# Altium schematic sheet [03]_Valve_Control.SchDoc([03]_Valve_Control)
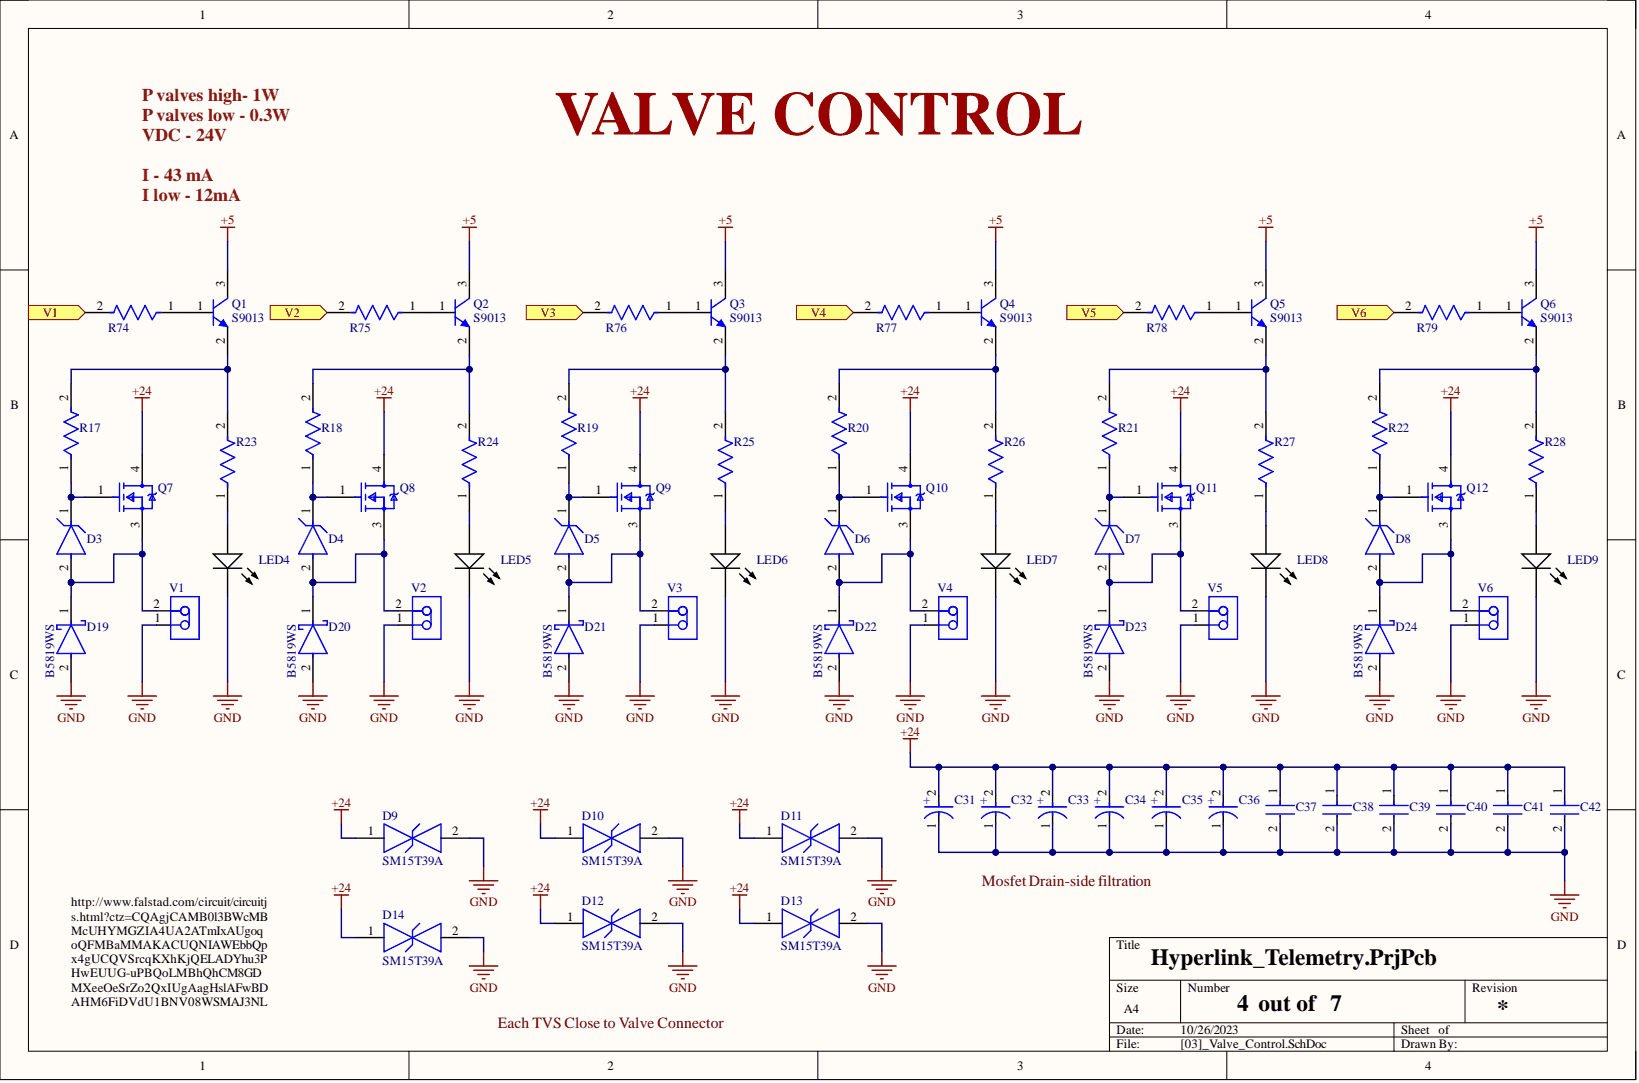
[diagram p0: [03]_Valve_Control.SchDoc]

## Parts
C31  EEEFK1V220R  (value not drawn)
C32  EEEFK1V220R  (value not drawn)
C33  EEEFK1V220R  (value not drawn)
C34  EEEFK1V220R  (value not drawn)
C35  EEEFK1V220R  (value not drawn)
C36  EEEFK1V220R  (value not drawn)
C37  TMK107BBJ475KA-T  (value not drawn)
C38  TMK107BBJ475KA-T  (value not drawn)
C39  TMK107BBJ475KA-T  (value not drawn)
C40  TMK107BBJ475KA-T  (value not drawn)
C41  TMK107BBJ475KA-T  (value not drawn)
C42  TMK107BBJ475KA-T  (value not drawn)
D3  BZT52C15  (value not drawn)
D4  BZT52C15  (value not drawn)
D5  BZT52C15  (value not drawn)
D6  BZT52C15  (value not drawn)
D7  BZT52C15  (value not drawn)
D8  BZT52C15  (value not drawn)
D9  SM15T39A
D10  SM15T39A
D11  SM15T39A
D12  SM15T39A
D13  SM15T39A
D14  SM15T39A
D19  B5819WS
D20  B5819WS
D21  B5819WS
D22  B5819WS
D23  B5819WS
D24  B5819WS
LED4  HSMW-C191  (value not drawn)
LED5  HSMW-C191  (value not drawn)
LED6  HSMW-C191  (value not drawn)
LED7  HSMW-C191  (value not drawn)
LED8  HSMW-C191  (value not drawn)
LED9  HSMW-C191  (value not drawn)
Q1  S9013
Q2  S9013
Q3  S9013
Q4  S9013
Q5  S9013
Q6  S9013
Q7  IRFR3410TRPBF  (value not drawn)
Q8  IRFR3410TRPBF  (value not drawn)
Q9  IRFR3410TRPBF  (value not drawn)
Q10  IRFR3410TRPBF  (value not drawn)
Q11  IRFR3410TRPBF  (value not drawn)
Q12  IRFR3410TRPBF  (value not drawn)
R17  0603WAF1200T5E  (value not drawn)
R18  0603WAF1200T5E  (value not drawn)
R19  0603WAF1200T5E  (value not drawn)
R20  0603WAF1200T5E  (value not drawn)
R21  0603WAF1200T5E  (value not drawn)
R22  0603WAF1200T5E  (value not drawn)
R23  CRCW06031K00FKEA  (value not drawn)
R24  CRCW06031K00FKEA  (value not drawn)
R25  CRCW06031K00FKEA  (value not drawn)
R26  CRCW06031K00FKEA  (value not drawn)
R27  CRCW06031K00FKEA  (value not drawn)
R28  CRCW06031K00FKEA  (value not drawn)
R74  0603WAF1200T5E  (value not drawn)
R75  0603WAF1200T5E  (value not drawn)
R76  0603WAF1200T5E  (value not drawn)
R77  0603WAF1200T5E  (value not drawn)
R78  0603WAF1200T5E  (value not drawn)
R79  0603WAF1200T5E  (value not drawn)
V1  1755736  (value not drawn)
V2  1755736  (value not drawn)
V3  1755736  (value not drawn)
V4  1755736  (value not drawn)
V5  1755736  (value not drawn)
V6  1755736  (value not drawn)

## Ports
V1, V2, V3, V4, V5, V6

## Nets
+5: Q1.3 Q2.3 Q3.3 Q4.3 Q5.3 Q6.3
+24: C31.2 C32.2 C33.2 C34.2 C35.2 C36.2 C37.1 C38.1 C39.1 C40.1 C41.1 C42.1 D9.1 D10.1 D11.1 D12.1 D13.1 D14.1 Q7.4 Q8.4 Q9.4 Q10.4 Q11.4 Q12.4
GND: C31.1 C32.1 C33.1 C34.1 C35.1 C36.1 C37.2 C38.2 C39.2 C40.2 C41.2 C42.2 D9.2 D10.2 D11.2 D12.2 D13.2 D14.2 D19.2 D20.2 D21.2 D22.2 D23.2 D24.2 LED4.2 LED5.2 LED6.2 LED7.2 LED8.2 LED9.2 V1.1 V2.1 V3.1 V4.1 V5.1 V6.1
V1: R74.2 ; port V1
V2: R75.2 ; port V2
V3: R76.2 ; port V3
V4: R77.2 ; port V4
V5: R78.2 ; port V5
V6: R79.2 ; port V6
NetD3_1: D3.1 Q7.1 R17.1
NetD3_2: D3.2 D19.1 Q7.3 V1.2
NetD4_1: D4.1 Q8.1 R18.1
NetD4_2: D4.2 D20.1 Q8.3 V2.2
NetD5_1: D5.1 Q9.1 R19.1
NetD5_2: D5.2 D21.1 Q9.3 V3.2
NetD6_1: D6.1 Q10.1 R20.1
NetD6_2: D6.2 D22.1 Q10.3 V4.2
NetD7_1: D7.1 Q11.1 R21.1
NetD7_2: D7.2 D23.1 Q11.3 V5.2
NetD8_1: D8.1 Q12.1 R22.1
NetD8_2: D8.2 D24.1 Q12.3 V6.2
NetLED4_1: LED4.1 R23.1
NetLED5_1: LED5.1 R24.1
NetLED6_1: LED6.1 R25.1
NetLED7_1: LED7.1 R26.1
NetLED8_1: LED8.1 R27.1
NetLED9_1: LED9.1 R28.1
NetQ1_1: Q1.1 R74.1
NetQ1_2: Q1.2 R17.2 R23.2
NetQ2_1: Q2.1 R75.1
NetQ2_2: Q2.2 R18.2 R24.2
NetQ3_1: Q3.1 R76.1
NetQ3_2: Q3.2 R19.2 R25.2
NetQ4_1: Q4.1 R77.1
NetQ4_2: Q4.2 R20.2 R26.2
NetQ5_1: Q5.1 R78.1
NetQ5_2: Q5.2 R21.2 R27.2
NetQ6_1: Q6.1 R79.1
NetQ6_2: Q6.2 R22.2 R28.2

## Library data of the parts
C31-C36 = CMP-05427-000063-1: CAP ALUM 22UF 20% 35V SMD; Dielectric Material: Aluminium; ESR (Equivalent Series Resistance): 700mR; Leakage Current: 3uA; Life (Hours): 2000Hour; Ripple Current: 160mA; Capacitance: 22uF; Case/Package: Radial; Component Kind: Standard; Construction: Cylindrical; Contact Plating: Tin; Diameter: 5mm; Height: 5.8mm; Height - Seated (Max): 0.228inch; Impedance: 700mR; JLC: C249982; Lead Free: Lead Free; Length: 5.8mm; Library Name: Altium Content Vault; Material: Aluminium; Max Operating Temperature: 105°C; Min Operating Temperature: -55°C; Mount: Surface Mount; Number of Pins: 2; Package Quantity: 1; Packaging: Tape and Reel; Radiation Hardening: No; REACH SVHC: No SVHC; Ripple Current (AC): 160mA; RoHS Compliant: Yes; Series: FK; Termination: SMD/SMT; Tolerance: 20%; Voltage: 35V; Voltage Rating: 35V; Voltage Rating (DC): 35V; Width: 6.5mm
C37-C42 = CMP-14477-004926-2: Multilayer Ceramic Capacitor 4.7uF 25V X5R 10% SMD 0603 T/R; Capacitance: 4.7uF; Case Code (Imperial): 0603; Case Code (Metric): 1608; Case/Package: 0603; Component Kind: Standard; Contact Plating: Tin; Dielectric: X5R; JLC: C19666; Lead Free: Lead Free; Length: 0.063inch; Library Name: Altium Content Vault; Max Operating Temperature: 85°C; Min Operating Temperature: -55°C; Mount: Surface Mount; Packaging: Tape and Reel; RoHS Compliant: Yes; Termination: Wraparound; Thickness: 0.039inch; Tolerance: 10%; Voltage Rating: 25V; Width: 0.031inch
D3-D8 = CMP-2000-05976-2: DIODE ZENER 15V 500MW SOD123; Manufacturer: Diodes Incorporated; Manufacturer Part Number: BZT52C5V1-7-F; Automotive Grade: NA; Forward Current: 10mA; Max Junction Temp: +150°C; Max Reverse Leakage Current: 2uA; Max Zener Voltage: 5.4V; Nom Zener Voltage: 5.1V; Power Dissipation: 370mW; Standoff Height: 0mm; Zener Impedance: 60Ω; Automotive: No; Category: Diode; Component Kind: Standard; ComponentLink2Description: Datasheet; ComponentLink2URL: https://www.diodes.com/assets/Datasheets/ds18004.pdf; Device Class L1: Discrete Semiconductors; Device Class L2: Diodes; Device Class L3: Zener Diodes; Digikey Description: DIODE ZENER 5.1V 500MW SOD123; Footprint Url: https://www.diodes.com/assets/Package-Files/SOD123.pdf; Height: 1.45mm; JLC: C173427; Lead Free: Yes; Library Name: Altium Content Vault; Mouser Description: Zener Diodes 5.1V 500mW; Octopart: https://octopart.com/bzt52c5v1-7-f-diodes+inc.-326718; Package: SOD123; RoHS: TRUE; Temperature: -65°C to +150°C; Temperature Range High: +150°C; Temperature Range Low: -65°C
D9-D14 = CMP-12225-000046-1: TVS DIODE 39V; Manufacturer: STMicroelectronics; Manufacturer Part Number: SM15T33CAY; Automotive Grade: Grade 0; Breakdown Voltage: 31.4V; Clamping Voltage: 45.7V; Direction: Bidirectional; Max Junction Temp: +150°C; Number Of Channels: 1; Peak Pulse Current: 33A; Peak Pulse Power: 1500W; Reverse Standoff Voltage: 28.2V; Standoff Height: 0.05mm; Automotive: Yes; Category: Diode; Component Kind: Standard; ComponentLink2Description: Datasheet; ComponentLink2URL: https://www.st.com/resource/en/datasheet/sm15ty.pdf; Device Class L1: Circuit Protection; Device Class L2: TVS Diodes; Device Class L3: unset; Digikey Description: TVS DIODE 28.2V 59V SMC; Height: 2.65mm; JLC: C1976564; Lead Free: Yes; Library Name: Altium Content Vault; Mouser Description: TVS Diodes - Transient Voltage Suppressors 1500 W 10kW Transil 5.8V tp 70V BI; Octopart: https://octopart.com/sm15t33cay-stmicroelectronics-19039450; Package: SMC-CA; RoHS: TRUE; Temperature: -40°C to +150°C; Temperature Range High: +150°C; Temperature Range Low: -40°C
D19-D24 = CMP-56206-000009-1: DIODE SCHOTTKY 40V 1A SOD323; Element Configuration: Single; Forward Voltage: 900mV; Max Reverse Leakage Current: 1mA; Max Surge Current: 10A; Average Rectified Current: 1A; Component Kind: Standard; JLC: C8598; Lead Free: Lead Free; Library Name: Altium Content Vault; Max Operating Temperature: 125°C; Max Reverse Voltage (DC): 40V; Min Operating Temperature: -65°C; Mount: Surface Mount; Number of Pins: 2; Package Quantity: 1; Packaging: Tape and Reel; Peak Non-Repetitive Surge Current: 10A; Radiation Hardening: No; RoHS Compliant: Yes; Series: -
LED4-LED9 = CMP-1744-00001-1: LED Uni-Color GREEN, 78 mW, 20 mA, -40 to 85 degC, 2-Pin SMD, RoHS, Tape and Reel; Current Rating: 300 mA; Component Kind: Standard; ComponentLink1Description: Manufacturer URL; ComponentLink1URL: http://www.avagotech.com/; ComponentLink2Description: Datasheet; ComponentLink2URL: http://docs.avagotech.com/docs/AV02-0186EN; Contact Finish: Silver; Contact Material: Copper; Contact Plating: Silver; DatasheetVersion: May-10; Height: 12 mm; JLC: C72038; Length: 16 mm; Library Name: Altium Content Vault; Max Operating Temperature: 85 degC; Min Operating Temperature: -20 degC; Mount: Through Hole; Package Quantity: 560; PackageDescription: 2-Pin Surface Mount Device, Body 1.6 x 0.8 mm; PackageReference: HSMW-C191; Packaging: Bulk; Radiation Hardening: No; REACH SVHC: Unknown; RoHSCompliant: true; Width: 12 mm
Q1-Q6 = CMP-1048-00662-1: NPN Silicon, 3-Pin SOT-23, Pb-Free, Tape and Reel; Manufacturer: On Semiconductor; PartNumber: MMBTA42LT1G; fT Min (MHz): 50; IC Continuous (A): 0.5; Component Kind: Standard; ComponentLink1Description: Manufacturer URL; ComponentLink1URL: http://www.onsemi.com/; ComponentLink2Description: Datasheet; ComponentLink2URL: http://www.onsemi.cn/pub_link/Collateral/MMBTA42LT1-D.PDF; ComponentLink3Description: Package Specification; ComponentLink3URL: http://www.onsemi.cn/pub_link/Collateral/318-08.PDF; DatasheetVersion: Rev. 12; JLC: C6749; Library Name: Altium Content Vault; Mounting Technology: Surface Mount; PackageDescription: 3-Pin Small Outline Transistor, Body 2.92 x 1.3 mm, Pitch 0.95 mm; PackageReference: SOT-23-3-318-08; PackageVersion: Rev. AP, 11/2009; Packing: Tape and Reel; Polarity: NPN; RoHS: TRUE
Q7-Q12 = CMP-32901-000329-1: MOSFET N-CH 100V 31A DPAK; Drain to Source Resistance: 39mR; Gate to Source Voltage (Vgs): 20V; Input Capacitance: 1.69nF; Max Power Dissipation: 3W; Power Dissipation: 110W; Threshold Voltage: 4V; Turn-Off Delay Time: 40ns; Turn-On Delay Time: 12ns; Case/Package: TO-252-3; Component Kind: Standard; Continuous Drain Current (ID): 31A; Drain to Source Breakdown Voltage: 100V; Drain to Source Voltage (Vdss): 100V; Halogen Free: Halogen Free; Height: 0.094inch; JLC: C2583; Lead Free: Contains Lead; Length: 0.264inch; Library Name: Altium Content Vault; Max Dual Supply Voltage: 100V; Max Operating Temperature: 175°C; Min Operating Temperature: -55°C; Mount: Surface Mount; Number of Pins: 3; Packaging: Tape and Reel; Rds On Max: 39mR; REACH SVHC: No SVHC; RoHS Compliant: Yes; Width: 0.245inch
R17-R22 R74-R79 = CMP-1012-00052-3: RES Thick Film, 120Ω, 5%, 0.1W, 200ppm/°C, 0603; Max Power Dissipation: 100mW; Resistance: 120R; Voltage Rating: 75V; Case Code (Imperial): 0603; Case Code (Metric): 1608; Case/Package: 0603; Component Kind: Standard; Composition: Thick Film; Contact Plating: Tin; Depth: 0.85mm; Features: Automotive AEC-Q200; Height: 0.02inch; JLC: C22787; Lead Free: Lead Free; Length: 1.55mm; Library Name: Altium Content Vault; Max Operating Temperature: 155°C; Military Standard: Not; Min Operating Temperature: -55°C; Number of Pins: 2; Number of Terminations: 2; Package Quantity: 1; Packaging: Tape and Reel; Power Rating: 100mW; Radiation Hardening: No; Temperature Coefficient: 200ppm/°C; Termination: SMD/SMT; Tolerance: 5%; Voltage Rating (DC): 75V; Weight: 7.1E-05oz; Width: 0.85mm
R23-R28 = CMP-1666-00003-5: RES Thick Film, 1kΩ, 1%, 0.1W, 100ppm/°C, 0603; Max Power Dissipation: 100mW; Resistance: 1kR; Voltage Rating: 75V; Case Code (Imperial): 0603; Case Code (Metric): 1608; Case/Package: 0603; Component Kind: Standard; Composition: Thick Film; Contact Plating: Tin; Depth: 0.85mm; Features: Automotive AEC-Q200; Height: 0.45mm; JLC: C21190; Lead Free: Lead Free; Length: 1.55mm; Library Name: Altium Content Vault; Max Operating Temperature: 155°C; Military Standard: Not; Min Operating Temperature: -55°C; Mount: Surface Mount; Number of Pins: 2; Number of Terminations: 2; Package Quantity: 10; Packaging: Tape and Reel; Power Rating: 100mW; Radiation Hardening: No; Temperature Coefficient: 100ppm/°C; Termination: SMD/SMT; Tolerance: 1%; Voltage Rating (DC): 75V; Weight: 7.1E-05oz; Width: 0.033inch
V1-V6 = CMP-2000-05300-1: Valve Connector, Pluggable Terminal 5.08 pitch; Manufacturer: Phoenix Contact; Content: 250pc(s); Industrial packaging: Yes; Number of contacts: 2; Pitch: 5.08mm; Colour: Green; Component Kind: Standard; Datasheet URL: http://www.mouser.com/ds/2/324/ItemDetail_1755736-921028.pdf; Datasheet Version: Apr-16; JLC: C8461; Library Name: Altium Content Vault; Manufacturer part No.: 1755736; Manufacturer URL: http://www.phoenixcontact.com/; Type: MSTBVA2,5/2-G-5,08
